# Altium schematic sheet ADC.SchDoc(ADC)
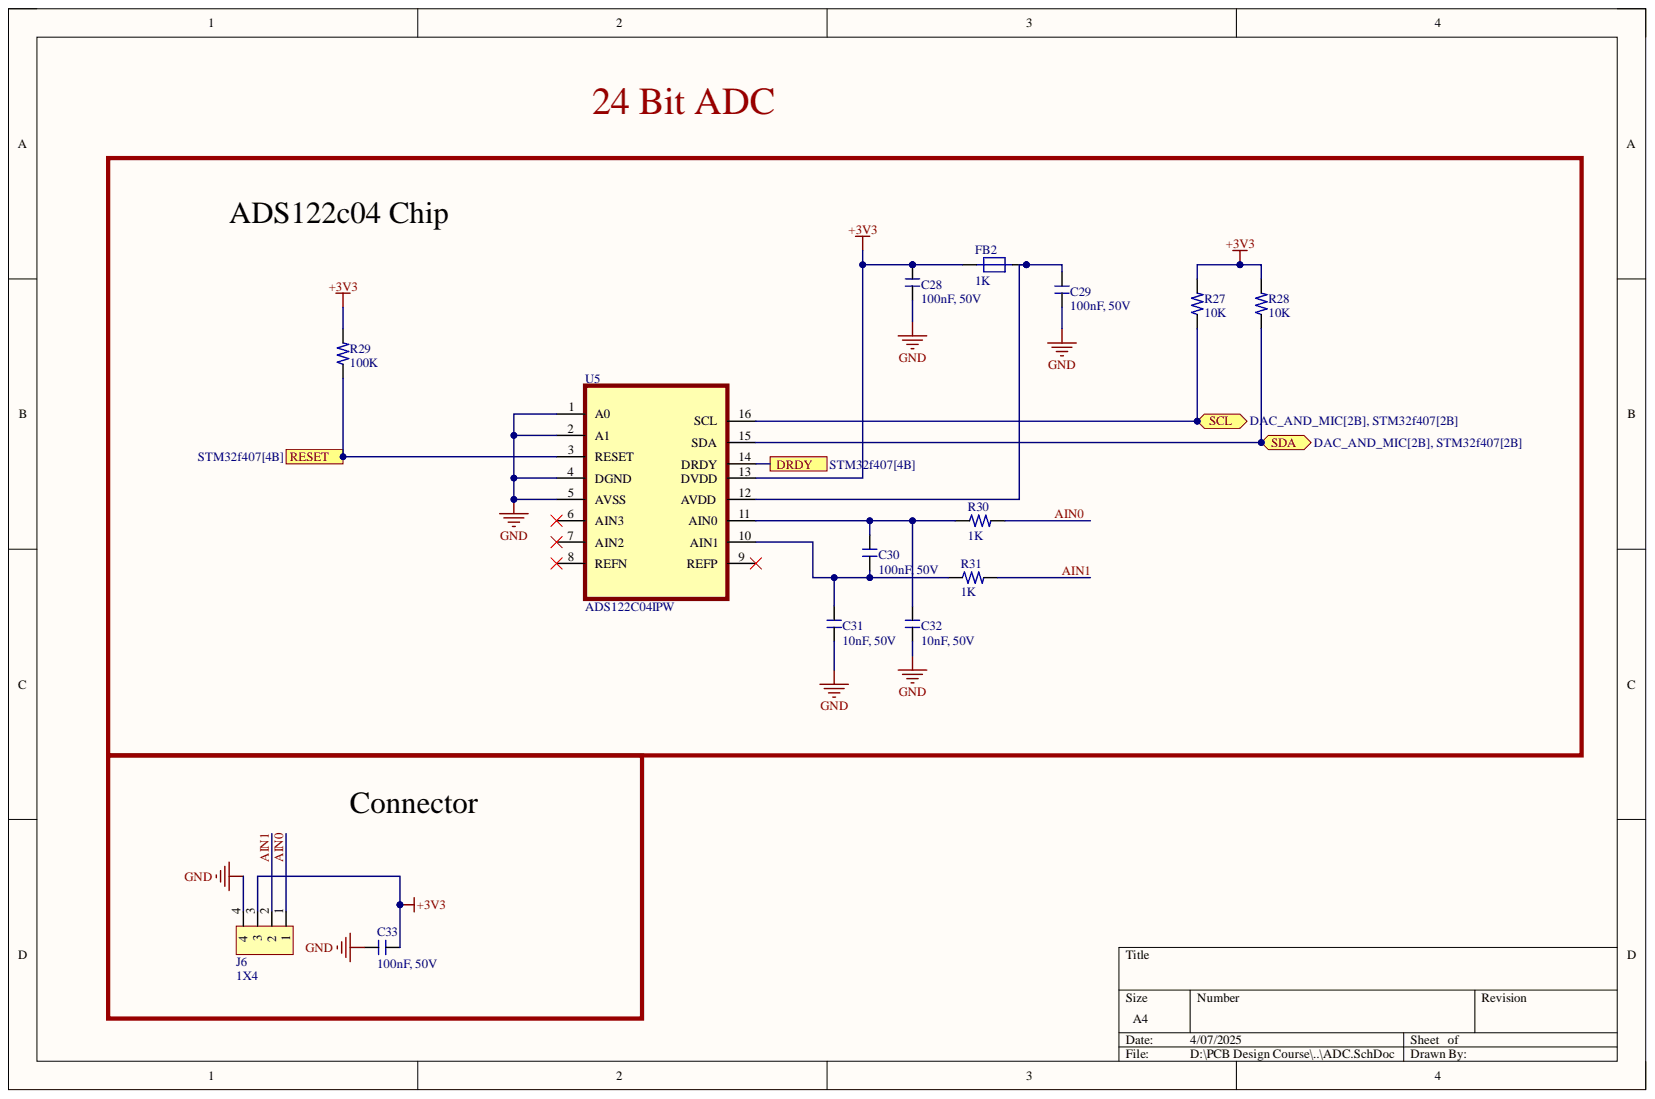
[diagram p0: ADC.SchDoc]

## Parts
C28  100nF, 50V  [Capacitor_0805]
C29  100nF, 50V  [Capacitor_0805]
C30  100nF, 50V  [Capacitor_0805]
C31  10nF, 50V  [Capacitor_0805]
C32  10nF, 50V  Voltage Rating (DC): 50V  [Capacitor_0805]
C33  100nF, 50V  Voltage: 50V  [Capacitor_0805]
FB2  1K  [FB_0805]
J6  1X4  [Header_1X4_2.54mm]
R27  10K  [Resistor_0603]
R28  10K  [Resistor_0603]
R29  100K  [Resistor_0603]
R30  1K  [Resistor_0603]
R31  1K  Voltage Rating: 50V  [Resistor_0603]
U5  ADS122C04IPW  [16_TSSOP]

## Ports
DRDY, RESET, SCL, SDA

## Nets
+3V3: C28.2 C33.2 FB2.1 J6.3 R27.2 R28.2 R29.2 U5.13(DVDD)
GND: C28.1 C29.1 C31.1 C32.1 C33.1 J6.4 U5.1(A0) U5.2(A1) U5.4(DGND) U5.5(AVSS)
AIN0: J6.1 R30.2
AIN1: J6.2 R31.2
DRDY: U5.14(DRDY) ; port DRDY
RESET: R29.1 U5.3(RESET) ; port RESET
SCL: R27.1 U5.16(SCL) ; port SCL
SDA: R28.1 U5.15(SDA) ; port SDA
NetC29_2: C29.2 FB2.2 U5.12(AVDD)
NetC30_1: C30.1 C31.2 R31.1 U5.10(AIN1)
NetC30_2: C30.2 C32.2 R30.1 U5.11(AIN0)

Not connected: U5 6(AIN3) 7(AIN2) 8(REFN) 9(REFP)

## Library data of the parts
C28-C30 C33 = Cap_100nF_0805: Multilayer Ceramic Capacitor, 0.1 uF, 50 V, ï¿½ 10%, X7R, 0805 [2012 Metric]; Manufacturer: Samsung; Manufacturer Part Number: CL21B104KBCNNNC; Case Code (Imperial): 0805; Case Code (Metric): 2012; Case/Package: 0805; Category: Ceramic Capacitors; Component Kind: Standard; ComponentLink1Description: https://datasheet.ciiva.com/25710/cl21b104kbcnnnc-25710182.pdf?src-supplier=Digikey; ComponentLink1URL: https://datasheet.ciiva.com/25710/cl21b104kbcnnnc-25710182.pdf?src-supplier=Digikey; ComponentLink2Description: https://datasheet.ciiva.com/29199/454152-da-01-en-vs-kondensator-0805-29199814.pdf?src-supplier=Conrad; ComponentLink2URL: https://datasheet.ciiva.com/29199/454152-da-01-en-vs-kondensator-0805-29199814.pdf?src-supplier=Conrad; Construction: Flat; Depth: 1.25mm; Dielectric: X7R; Height: 0.85mm; Lead Free: 5697LeadFree; Length: 2mm; Material: Ceramic; Max Operating Temperature: 125°C; Min Operating Temperature: -55°C; Mounting Technology: Surface Mount; Packaging: Tape and Reel; Pricing: 1=0,08, 10=0,012, 100=0,0104, 1000=0,008 (USD); Radiation Hardening: No; RoHS: Yes; RoHS Compliant: Yes; Series: CL; Stock: 674609; Supplier: Digikey; Supplier Part Number: 1276-1003-1-ND; Thickness: 0.037inch; Tolerance: 10%; Value: 100nF; Voltage Rating: 50V; Voltage Rating (DC): 50V; Width: 0.049inch; Library Name: Schematics_Library_Hanif.SchLib
  C28-C30: Voltage: 50V
C31 C32 = Cap_10nF_0805: Multilayer Ceramic Capacitors MLCC - SMD/SMT 50V 0.01uF X7R 0805 10% AEC-Q200; Manufacturer: KEMET; Manufacturer Part Number: C0805C103K5RECAUTO; Dielectric: 1511DielectricType; Category: Ceramic Capacitors; Component Kind: Standard; ComponentLink1Description: http://www.farnell.com/datasheets/2723804.pdf?src-supplier=Farnell; ComponentLink1URL: http://www.farnell.com/datasheets/2723804.pdf?src-supplier=Farnell; ComponentLink2Description: https://api.kemet.com/component-edge/download/datasheet/C0805C103K5RECAUTO.pdf?src-supplier=Digikey; ComponentLink2URL: https://api.kemet.com/component-edge/download/datasheet/C0805C103K5RECAUTO.pdf?src-supplier=Digikey; ComponentLink3Description: http://www.farnell.com/datasheets/2723804.pdf?src-supplier=Newark; ComponentLink3URL: http://www.farnell.com/datasheets/2723804.pdf?src-supplier=Newark; Pricing: 1=0,22, 10=0,0376 (USD); RoHS: Yes; Stock: 16; Supplier: Arrow Electronics; Supplier Part Number: C0805C103K5RECAUTO; Library Name: Schematics_Library_Hanif.SchLib
  C31: Voltage Rating (DC): 50V
FB2 = FB_BLM21SP102SH1D: Ferrite Beads Multi-Layer High Current 1KOhm 25% 100MHz 1.6A 0.12Ohm DCR 0805 Automotive T/R; Manufacturer: Murata; Core Material: 228ConductorMaterial; ESR: 120mR; Value: 120mR; Category: Ferrite Beads and Chips; Component Kind: Standard; ComponentLink1Description: https://datasheet.octopart.com/BLM21SP102SH1D-Murata-datasheet-138707452.pdf?src-supplier=Future+Electronics; ComponentLink1URL: https://datasheet.octopart.com/BLM21SP102SH1D-Murata-datasheet-138707452.pdf?src-supplier=Future+Electronics; ComponentLink2Description: https://www.murata.com/en-us/api/pdfdownloadapi?cate=luNoiseSupprFilteChipFerriBead&amp;partno=BLM21SP102SH1%23&src-supplier=Digikey; ComponentLink2URL: https://www.murata.com/en-us/api/pdfdownloadapi?cate=luNoiseSupprFilteChipFerriBead&amp;partno=BLM21SP102SH1%23&src-supplier=Digikey; ComponentLink3Description: https://datasheet.octopart.com/BLM21SP102SH1D-Murata-datasheet-166171483.pdf?src-supplier=TME; ComponentLink3URL: https://datasheet.octopart.com/BLM21SP102SH1D-Murata-datasheet-166171483.pdf?src-supplier=TME; Height: 1.05mm; Manufacturer Part Number: BLM21SP102SH1D; Pricing: 1=0,33, 10=0,179, 50=0,179, 100=0,159, 1000=0,105, 10000=0,083 (USD); RMS Current (Irms): 1.6A; RoHS: Yes; Stock: 4751; Supplier: Mouser; Supplier Part Number: 81-BLM21SP102SH1D; Test Frequency: 100MHz; Tolerance: 25%; Library Name: Schematics_Library_Hanif.SchLib
J6 = Header_Male_1X4_2.54: THT Vertical Pin Header WR-PHD, Pitch 2.54 mm, Single Row, 4 pins; Manufacturer: Wurth Electronics; Manufacturer Part Number: 61300411121; Contact Resistance: 20mR; Current Rating: 3A; Gender: 29Gender; Number of Contacts: 4; Operating Supply Voltage: 250V; Pitch: 0.1inch; Category: Headers and Wire Housings; Color: Black; Component Kind: Standard; ComponentLink1Description: http://www.farnell.com/cad/1737268.pdf?src-supplier=Element14; ComponentLink1URL: http://www.farnell.com/cad/1737268.pdf?src-supplier=Element14; ComponentLink2Description: https://datasheet.octopart.com/61300411121-W%C3%BCrth-Elektronik-datasheet-21154836.pdf?src-supplier=Element14; ComponentLink2URL: https://datasheet.octopart.com/61300411121-W%C3%BCrth-Elektronik-datasheet-21154836.pdf?src-supplier=Element14; ComponentLink3Description: https://katalog.we-online.de/em/datasheet/6130xx11121.pdf?src-supplier=Digikey; ComponentLink3URL: https://katalog.we-online.de/em/datasheet/6130xx11121.pdf?src-supplier=Digikey; Contact Material: Copper; Contact Mating Length: 0.236inch; Contact Plating: Gold; Contact Type: Male Pin; Height: 8.89mm; Lead Free: 5697LeadFree; Max Operating Temperature: 105°C; Min Operating Temperature: -40°C; Mounting Technology: Through Hole; Number of Positions: 4; Number of Rows: 1; Orientation: 5495OrientationType; Packaging: Bulk; Pricing: 1=0,17, 10=0,147, 25=0,1216, 50=0,1018, 100=0,0918, 1000=0,085 (USD); Reach SVHC Compliant: Unknown; RoHS: Yes; RoHS Compliant: Yes; Series: WR-PHD; Stock: 28749; Supplier: Digikey; Supplier Part Number: 732-5317-ND; Termination: Solder; Voltage Rating: 250V; Library Name: Schematics_Library_Hanif.SchLib
R27 R28 = R_10K_0603: SMD Chip Resistor, 10 kOhm, ï¿½ 1%, 100 mW, 0603 [1608 Metric], Thick Film, General Purpose; Manufacturer: Yageo; Manufacturer Part Number: RC0603FR-0710KL; Max Power Dissipation: 100mW; Temperature Coefficient: 100ppm/°C; Value: 10kR; Voltage Rating: 75V; Voltage Rating (DC): 50V; Case Code (Imperial): 0603; Case Code (Metric): 1608; Case/Package: 0603; Category: Chip SMD Resistors; Component Kind: Standard; ComponentLink1Description: https://datasheet.ciiva.com/7660/38590-7660789.pdf?src-supplier=Element14; ComponentLink1URL: https://datasheet.ciiva.com/7660/38590-7660789.pdf?src-supplier=Element14; ComponentLink2Description: https://datasheet.ciiva.com/7983/443971-7983596.pdf?src-supplier=Element14; ComponentLink2URL: https://datasheet.ciiva.com/7983/443971-7983596.pdf?src-supplier=Element14; ComponentLink3Description: https://datasheet.ciiva.com/22747/443971-22747519.pdf?src-supplier=Element14; ComponentLink3URL: https://datasheet.ciiva.com/22747/443971-22747519.pdf?src-supplier=Element14; ComponentLink4Description: https://datasheet.ciiva.com/46440/1868787-46440891.pdf?src-supplier=Element14; ComponentLink4URL: https://datasheet.ciiva.com/46440/1868787-46440891.pdf?src-supplier=Element14; ComponentLink5Description: https://datasheet.ciiva.com/7746/1738695-7746239.pdf?src-supplier=Element14; ComponentLink5URL: https://datasheet.ciiva.com/7746/1738695-7746239.pdf?src-supplier=Element14; ComponentLink6Description: https://datasheet.ciiva.com/15189/getdatasheetpartid-409289-15189591.pdf?src-supplier=Verical; ComponentLink6URL: https://datasheet.ciiva.com/15189/getdatasheetpartid-409289-15189591.pdf?src-supplier=Verical; Construction: Rectangular; Depth: 0.8mm; Features: Moisture Resistant; Height: 0.45mm; Lead Free: 5697LeadFree; Lead Pitch: 0.3mm; Length: 1.6mm; Max Operating Temperature: 155°C; Military Standard: Not; Min Operating Temperature: -55°C; Number of Terminations: 2; Packaging: Tape and Reel; Pins: 2; Power Rating: 100mW; Pricing: 1=0,1, 10=0,009, 100=0,0071, 1000=0,00612 (USD); Reach SVHC Compliant: No; Resistance Tolerance: 1%; Resistor Type: Thick Film; RoHS: Yes; RoHS Compliant: Yes; Series: RC; Stock: 2808060; Supplier: Digikey; Supplier Part Number: 311-10.0KHRCT-ND; Termination: SMD/SMT; Tolerance: 1%; Weight: 7.1E-05oz; Width: 0.8mm; Library Name: Schematics_Library_Hanif.SchLib
R29 = R_100K_0603: Res Thick Film 0603 100K Ohm 1% 0.1W(1/10W) ±100ppm/C Pad SMD Automotive T/R; Manufacturer: Stackpole Electronics; Manufacturer Part Number: RMCF0603FT100K; Max Power Dissipation: 100mW; Temperature Coefficient: 100ppm/°C; Value: 100kR; Voltage Rating: 75V; Case Code (Imperial): 0603; Case Code (Metric): 1608; Case/Package: 0603; Category: Chip SMD Resistors; Component Kind: Standard; ComponentLink1Description: https://datasheet.ciiva.com/15193/getdatasheetpartid-362597-15193437.pdf?src-supplier=Verical; ComponentLink1URL: https://datasheet.ciiva.com/15193/getdatasheetpartid-362597-15193437.pdf?src-supplier=Verical; Construction: Rectangular; Contact Plating: Tin,Matte; Depth: 0.8mm; Features: Automotive AEC-Q200; Height: 0.022inch; Lead Free: 5697LeadFree; Length: 1.55mm; Max Operating Temperature: 155°C; Military Standard: Not; Min Operating Temperature: -55°C; Mounting Technology: Surface Mount; Number of Terminations: 2; Packaging: Tape and Reel; Pricing: 1=0,1, 10=0,02, 100=0,0091, 1000=0,0048, 2500=0,00386 (USD); Radiation Hardening: No; RoHS: Yes; RoHS Compliant: Yes; Series: RMCF; Stock: 722640; Supplier: Digikey; Supplier Part Number: RMCF0603FT100KCT-ND; Tolerance: 1%; Width: 0.031inch; Library Name: Schematics_Library_Hanif.SchLib
R30 = R_1k_0603: Res Thick Film 0603 1K Ohm 1% 0.1W(1/10W) ±100ppm/C Pad SMD T/R; Manufacturer: Walsin Technologies; Manufacturer Part Number: WR06X1001FTL; Power Rating: 100mW; Temperature Coefficient: 100ppm/°C; Value: 1kR; Voltage Rating: 50V; Voltage Rating (DC): 75V; Case Code (Imperial): 0603; Case Code (Metric): 1608; Case/Package: 0603; Category: Chip SMD Resistors; Component Kind: Standard; ComponentLink1Description: https://datasheet.ciiva.com/26946/getdatasheetpartid-137128-26946327.pdf?src-supplier=Verical; ComponentLink1URL: https://datasheet.ciiva.com/26946/getdatasheetpartid-137128-26946327.pdf?src-supplier=Verical; Construction: Rectangular; Contact Material: 154ConductorMaterial; Depth: 0.8mm; Height: 0.45mm; Lead Free: 5697LeadFree; Length: 1.6mm; Max Operating Temperature: 155°C; Military Standard: Not; Min Operating Temperature: -55°C; Mounting Technology: Surface Mount; Package Quantity: 5000; Packaging: Tape and Reel; Pricing: 1=0,1, 10=0,015, 50=0,0086, 100=0,0068, 500=0,00418, 1000=0,00345 (USD); Reach SVHC Compliant: Unknown; Resistance Tolerance: 1%; Resistor Type: Thick Film; RoHS: Yes; RoHS Compliant: Yes; Series: WR06X; Stock: 17; Supplier: Digikey; Supplier Part Number: 1292-WR06X1001FTLCT-ND; Termination: SMD/SMT; Tolerance: 1%; Width: 0.8mm; Library Name: Schematics_Library_Hanif.SchLib
R31 = R_1k_0603: Res Thick Film 0603 1K Ohm 1% 0.1W(1/10W) ±100ppm/C Pad SMD T/R; Manufacturer: Walsin Technologies; Manufacturer Part Number: WR06X1001FTL; Power Rating: 100mW; Temperature Coefficient: 100ppm/°C; Value: 1kR; Voltage Rating (DC): 75V; Case Code (Imperial): 0603; Case Code (Metric): 1608; Case/Package: 0603; Category: Chip SMD Resistors; Component Kind: Standard; ComponentLink1Description: https://datasheet.ciiva.com/26946/getdatasheetpartid-137128-26946327.pdf?src-supplier=Verical; ComponentLink1URL: https://datasheet.ciiva.com/26946/getdatasheetpartid-137128-26946327.pdf?src-supplier=Verical; Construction: Rectangular; Contact Material: 154ConductorMaterial; Depth: 0.8mm; Height: 0.45mm; Lead Free: 5697LeadFree; Length: 1.6mm; Max Operating Temperature: 155°C; Military Standard: Not; Min Operating Temperature: -55°C; Mounting Technology: Surface Mount; Package Quantity: 5000; Packaging: Tape and Reel; Pricing: 1=0,1, 10=0,015, 50=0,0086, 100=0,0068, 500=0,00418, 1000=0,00345 (USD); Reach SVHC Compliant: Unknown; Resistance Tolerance: 1%; Resistor Type: Thick Film; RoHS: Yes; RoHS Compliant: Yes; Series: WR06X; Stock: 17; Supplier: Digikey; Supplier Part Number: 1292-WR06X1001FTLCT-ND; Termination: SMD/SMT; Tolerance: 1%; Width: 0.8mm; Library Name: Schematics_Library_Hanif.SchLib
U5 = IC_ADC_ADS122C04IPW: ADC Quad Delta-Sigma 2ksps 24-bit Serial (I2C) 16-Pin TSSOP Tube; Manufacturer: Texas Instruments; Category: Analog to Digital Converters (ADCs); Component Kind: Standard; ComponentLink1Description: http://www.ti.com/lit/ds/symlink/ads122c04.pdf?src-supplier=Digikey; ComponentLink1URL: http://www.ti.com/lit/ds/symlink/ads122c04.pdf?src-supplier=Digikey; Manufacturer Part Number: ADS122C04IPW; Pricing: 1=8,29, 10=6,48, 50=5,74, 100=5,73, 1000=5,73, 10000=5,73 (USD); RoHS: Yes; Stock: 2028; Supplier: Mouser; Supplier Part Number: 595-ADS122C04IPW; Library Name: Schematics_Library_Hanif.SchLib
